# Altium schematic sheet 02_GAP9_CHIP_POWER.SchDoc
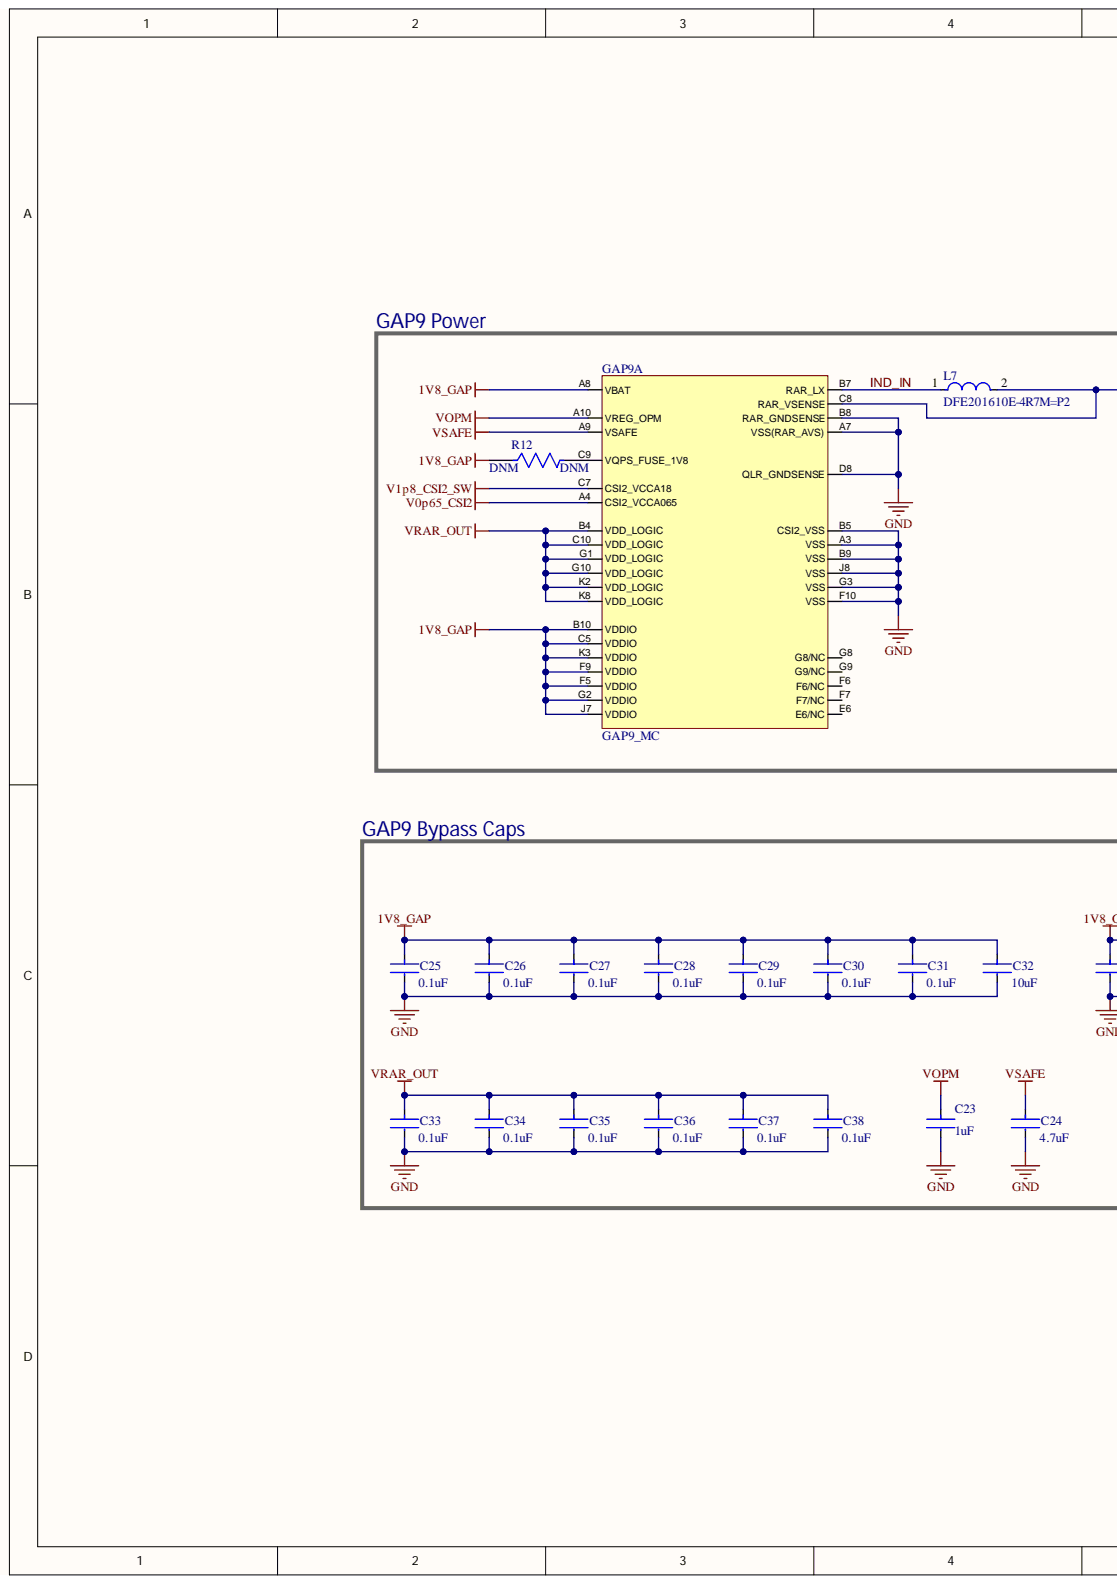
[diagram p0: 02_GAP9_CHIP_POWER.SchDoc, left]
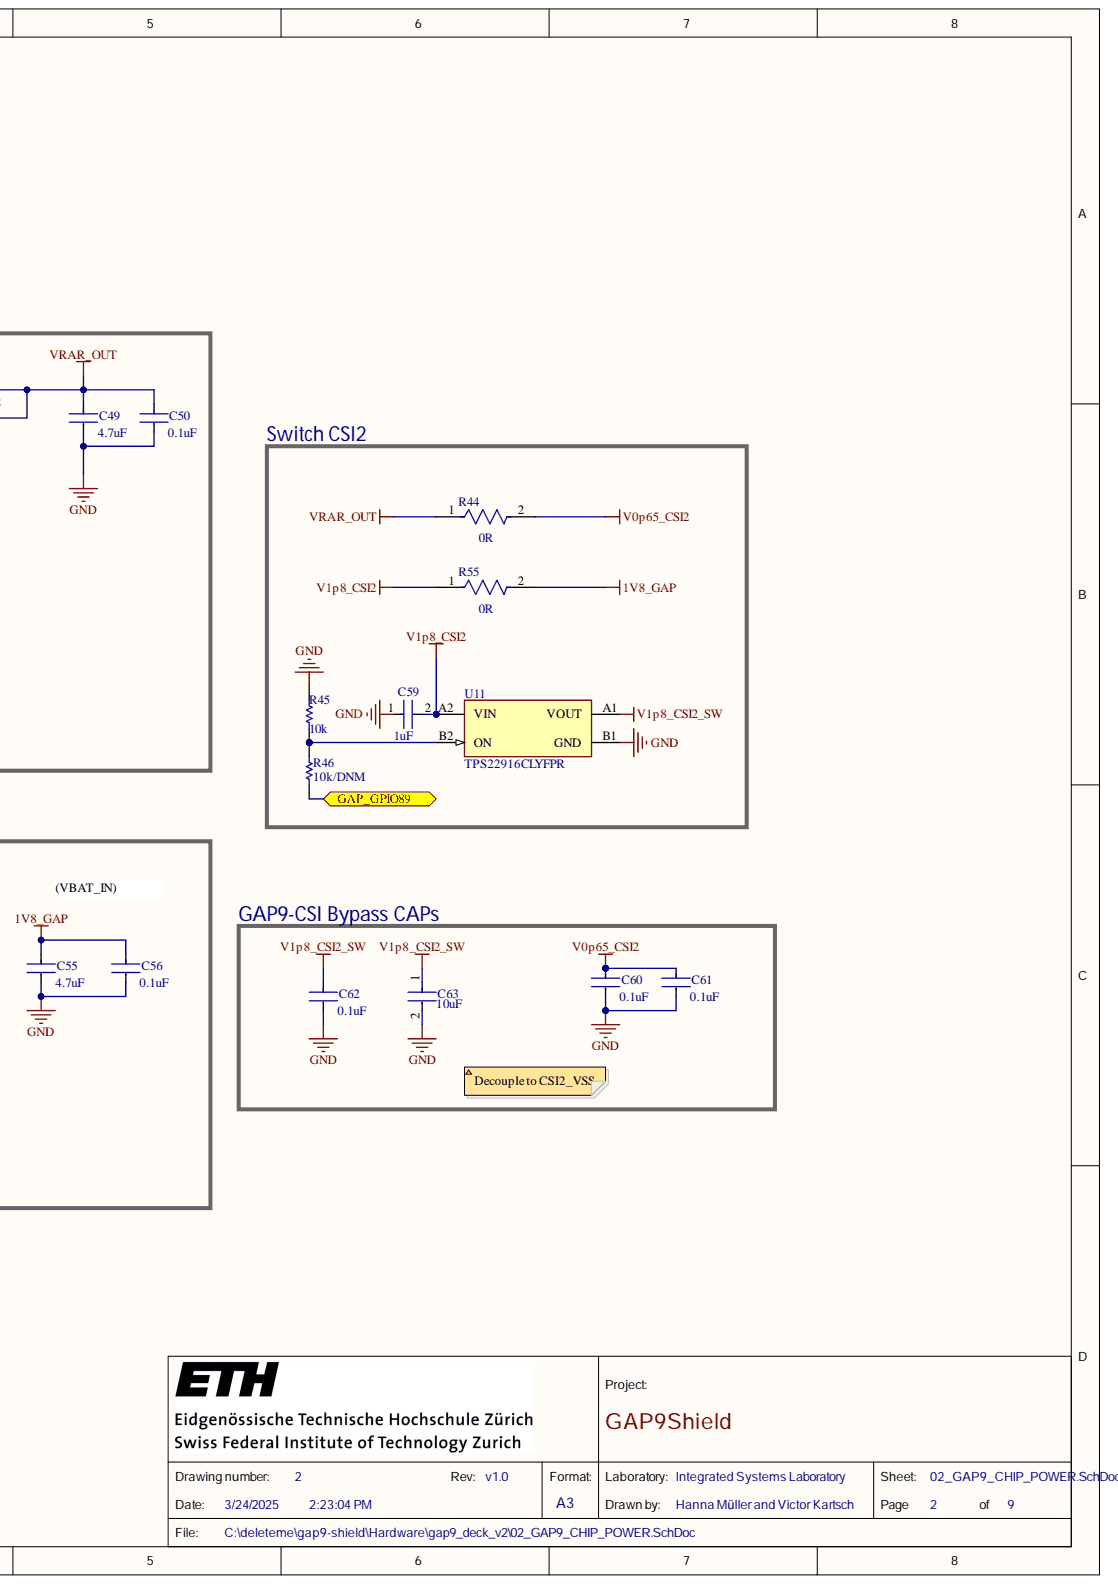
[diagram p1: 02_GAP9_CHIP_POWER.SchDoc, right]

## Parts
C23  value 1uF  Capacitance: 1uF  [FP-CL03-IPC_B]  (hidden comment: CL03A105KP3NSNC)
C24  value 4.7uF  Capacitance: 4.7uF  [FP-C1005-050-0_15-IPC_B]  (hidden comment: C1005X5R0J475K050BC)
C25  value 0.1uF  Capacitance: 0.1uF  [FP-GRM033-0_03-IPC_B]  (hidden comment: GRM033Z71C104KE14D)
C26  value 0.1uF  Capacitance: 0.1uF  [FP-GRM033-0_03-IPC_B]  (hidden comment: GRM033Z71C104KE14D)
C27  value 0.1uF  Capacitance: 0.1uF  [FP-GRM033-0_03-IPC_B]  (hidden comment: GRM033Z71C104KE14D)
C28  value 0.1uF  Capacitance: 0.1uF  [FP-GRM033-0_03-IPC_B]  (hidden comment: GRM033Z71C104KE14D)
C29  value 0.1uF  Capacitance: 0.1uF  [FP-GRM033-0_03-IPC_B]  (hidden comment: GRM033Z71C104KE14D)
C30  value 0.1uF  Capacitance: 0.1uF  [FP-GRM033-0_03-IPC_B]  (hidden comment: GRM033Z71C104KE14D)
C31  value 0.1uF  Capacitance: 0.1uF  [FP-GRM033-0_03-IPC_B]  (hidden comment: GRM033Z71C104KE14D)
C32  value 10uF  Capacitance: 10uF  [FP-CL05A106MP5NUNC-IPC_B]  (hidden comment: CL05A106MP5NUNC)
C33  value 0.1uF  Capacitance: 0.1uF  [FP-GRM033-0_03-IPC_B]  (hidden comment: GRM033Z71C104KE14D)
C34  value 0.1uF  Capacitance: 0.1uF  [FP-GRM033-0_03-IPC_B]  (hidden comment: GRM033Z71C104KE14D)
C35  value 0.1uF  Capacitance: 0.1uF  [FP-GRM033-0_03-IPC_B]  (hidden comment: GRM033Z71C104KE14D)
C36  value 0.1uF  Capacitance: 0.1uF  [FP-GRM033-0_03-IPC_B]  (hidden comment: GRM033Z71C104KE14D)
C37  value 0.1uF  Capacitance: 0.1uF  [FP-GRM033-0_03-IPC_B]  (hidden comment: GRM033Z71C104KE14D)
C38  value 0.1uF  Capacitance: 0.1uF  [FP-GRM033-0_03-IPC_B]  (hidden comment: GRM033Z71C104KE14D)
C49  value 4.7uF  Capacitance: 4.7uF  [FP-C1005-050-0_15-IPC_B]  (hidden comment: C1005X5R0J475K050BC)
C50  value 0.1uF  Capacitance: 0.1uF  [FP-GRM033-0_03-IPC_B]  (hidden comment: GRM033Z71C104KE14D)
C55  value 4.7uF  Capacitance: 4.7uF  [FP-C1005-050-0_15-IPC_B]  (hidden comment: C1005X5R0J475K050BC)
C56  value 0.1uF  Capacitance: 0.1uF  [FP-GRM033-0_03-IPC_B]  (hidden comment: GRM033Z71C104KE14D)
C59  value 1uF  Capacitance: 1uF  [FP-GRM033-0_09-IPC_C]  (hidden comment: GRM033C81A105ME05D)
C60  value 0.1uF  Capacitance: 0.1uF  [FP-GRM033-0_03-IPC_B]  (hidden comment: GRM033Z71C104KE14D)
C61  value 0.1uF  Capacitance: 0.1uF  [FP-GRM033-0_03-IPC_B]  (hidden comment: GRM033Z71C104KE14D)
C62  value 0.1uF  Capacitance: 0.1uF  [FP-GRM033-0_03-IPC_B]  (hidden comment: GRM033Z71C104KE14D)
C63  value 10uF  Capacitance: 10uF  [FP-CL05A106MP5NUNC-MFG]  (hidden comment: CL05A106MP5NUNC)
GAP9  GAP9_MC  [GAP9]  (part A)
L7  DFE201610E-4R7M=P2  [FP-DFE201610C-MFG]
R12  DNM  [0402]
R44  value 0R  Resistance: 0R  [FP-CRCW0402-C-e3-MFG]  (hidden comment: CRCW04020000Z0EDC)
R45  value 10k  [RESC0603X28X15LL05T05]  (hidden comment: CRCW020110K0FNED)
R46  value 10k/DNM  [RESC0603X28X15LL05T05]  (hidden comment: CRCW020110K0FNED)
R55  value 0R  Resistance: 0R  [FP-CRCW0402-C-e3-MFG]  (hidden comment: CRCW04020000Z0EDC)
U11  TPS22916CLYFPR  [FP-YFP0004-IPC_C]

## Ports
GAP_GPIO89

## Nets
1V8_GAP: C25.1 C26.1 C27.1 C28.1 C29.1 C30.1 C31.1 C32.1 C55.1 C56.1 GAP9.A8(VBAT) GAP9.B10(VDDIO) GAP9.C5(VDDIO) GAP9.F5(VDDIO) GAP9.F9(VDDIO) GAP9.G2(VDDIO) GAP9.J7(VDDIO) GAP9.K3(VDDIO) R12.1 R55.2
GND: C23.2 C24.2 C25.2 C26.2 C27.2 C28.2 C29.2 C30.2 C31.2 C32.2 C33.2 C34.2 C35.2 C36.2 C37.2 C38.2 C49.2 C50.2 C55.2 C56.2 C59.1 C60.2 C61.2 C62.2 C63.2 GAP9.A3(VSS) GAP9.A7(VSS(RAR_AVS)) GAP9.B5(CSI2_VSS) GAP9.B8(RAR_GNDSENSE) GAP9.B9(VSS) GAP9.D8(QLR_GNDSENSE) GAP9.F10(VSS) GAP9.G3(VSS) GAP9.J8(VSS) R45.2 U11.B1(GND)
V0p65_CSI2: C60.1 C61.1 GAP9.A4(CSI2_VCCA065) R44.2
V1p8_CSI2: C59.2 R55.1 U11.A2(VIN)
V1p8_CSI2_SW: C62.1 C63.1 GAP9.C7(CSI2_VCCA18) U11.A1(VOUT)
VOPM: C23.1 GAP9.A10(VREG_OPM)
VRAR_OUT: C33.1 C34.1 C35.1 C36.1 C37.1 C38.1 C49.1 C50.1 GAP9.B4(VDD_LOGIC) GAP9.C8(RAR_VSENSE) GAP9.C10(VDD_LOGIC) GAP9.G1(VDD_LOGIC) GAP9.G10(VDD_LOGIC) GAP9.K2(VDD_LOGIC) GAP9.K8(VDD_LOGIC) L7.2 R44.1
VSAFE: C24.1 GAP9.A9(VSAFE)
GAP_GPIO89: R46.2 ; port GAP_GPIO89
IND_IN: GAP9.B7(RAR_LX) L7.1
NetGAP9_C9: GAP9.C9(VQPS_FUSE_1V8) R12.2
NetR45_1: R45.1 R46.1 U11.B2(ON)

Not connected: GAP9 E6(E6/NC) F6(F6/NC) F7(F7/NC) G8(G8/NC) G9(G9/NC)

## Library data of the parts
C23 = CMP-13271-003594-1: Cap Ceramic 1uF 10V X5R ±10% Pad SMD 0201 +85°C T/R; Case Code (Imperial): 0201; Case Code (Metric): 0603; Case/Package: 0201; Component Kind: Standard; Length: 0.024inch; Library Name: Altium Content Vault; Max Operating Temperature: 85°C; Min Operating Temperature: -55°C; Mount: Surface Mount; Packaging: Cut Tape; Thickness: 0.013inch; Tolerance: 10%; Voltage Rating: 10V; Width: 0.012inch
C24 C49 C55 = CMP-2000-05437-2: Chip Multilayer Ceramic Capacitors for General Purpose, 0402, 4.7uF; Dielectric: X5R; Tolerance: 10%; Voltage: 6.3V; Case/Package: 0402; Component Kind: Standard; Depth: 0.5mm; Dielectric Material: Ceramic; Height: 0.5mm; Lead Free: Lead Free; Length: 1mm; Library Name: Altium Content Vault; Max Operating Temperature: 85°C; Min Operating Temperature: -55°C; Mount: Surface Mount; RoHS Compliant: Yes; Series: C; Termination: SMD/SMT
C25-C31 C33-C38 C50 C56 C60-C62 = CMP-06035-035508-1: Chip Multilayer Ceramic Capacitors for General Purpose, 0201, 0.10uF, X7R, 15%, 10%, 16V; Case/Package: 0201; Component Kind: Standard; Library Name: Altium Content Vault
C32 C63 = CMP-13271-000002-1: CAP CER 10UF 10V X5R 0402; Manufacturer: Samsung; Material: Ceramic; Temperature Coefficient: 15%; Tolerance: 20%; Automotive: No; Category: Cap; Component Kind: Standard; ComponentLink2Description: Datasheet; ComponentLink2URL: http://www.samsungsem.com/kr/support/product-search/mlcc/__icsFiles/afieldfile/2019/05/10/19-CL05A106MP5NUNC.pdf; Device Class L1: Passive Components; Device Class L2: Capacitors; Device Class L3: Ceramic Capacitors; Digikey Description: CAP CER 10UF 10V X5R 0402; Height: 0.7mm; Ipc Land Pattern Name: CAPC10050X50; Lead Free: Yes; Library Name: Altium Content Vault; Manufacturer Part Number: CL05A106MP5NUNC; Octopart: https://octopart.com/cl05a106mp5nunc-samsung-22457395; Package: CL05A106MP5NUNC; RoHS: TRUE; Temperature: -55°C to +85°C; Temperature Characteristic: X5R; Temperature Range High: +85°C; Temperature Range Low: -55°C; Voltage Rating: 10V
C59 = CMP-06035-000112-2: Chip Multilayer Ceramic Capacitors for General Purpose, 0201, 1.0uF, X6S, 22%, 20%, 10V; Case Code (Imperial): 0201; Case Code (Metric): 0603; Case/Package: 0201; Component Kind: Standard; Dielectric: X6S; Length: 0.024inch; Library Name: Altium Content Vault; Max Operating Temperature: 105°C; Min Operating Temperature: -55°C; Mount: Surface Mount; Packaging: Tape and Reel; Thickness: 0.015inch; Tolerance: 20%; Voltage Rating: 10V; Voltage Rating (DC): 10V; Width: 0.012inch
L7 = CMP-06042-006199-1: Shielded Wirewound Inductor 4.7uH 20% 1100mA 0.288Ω 0806 (2016); Component Kind: Standard; Library Name: Altium Content Vault; value: 4.7 uH
R12 = RES DNM 0402: Resistor; Component Kind: Standard; Library Name: EEG_SchLib.SchLib; Value: DNM
R44 R55 = CMP-02407-011555-1: RES 0 OHM JUMPER 1/16W 0402; Power Rating: 62.5mW; Case/Package: Molded; Component Kind: Standard; Contact Plating: Tin; Lead Free: Lead Free; Length: 1mm; Library Name: Altium Content Vault; Max Operating Temperature: 155°C; Min Operating Temperature: -55°C; Mount: Surface Mount; Resistor Type: Thick Film; RoHS Compliant: Yes; Termination: Wraparound
R45 R46 = CMP-2004-00016-1: Manufacturer: Vishay Dale; Component Kind: Standard; Library Name: Altium Content Vault; Part Number: CRCW020110K0FNED
U11 = CMP-04910-000140-1: IC PWR SWITCH P-CHAN 1:1 4DSBGA; Fault Protection: Reverse Current; Max Output Current: 2A; Number of Channels: 1; Output Configuration: High Side; Component Kind: Standard; Library Name: Altium Content Vault; Max Operating Temperature: 85°C; Min Operating Temperature: -40°C; Number of Outputs: 1; Packaging: Tape and Reel; RoHS Compliant: Yes
GAP9 = GAP9_MC: Component Kind: Standard; Library Name: EEG_SchLib.SchLib
